# Altium schematic sheet RS485.SchDoc(RS485)
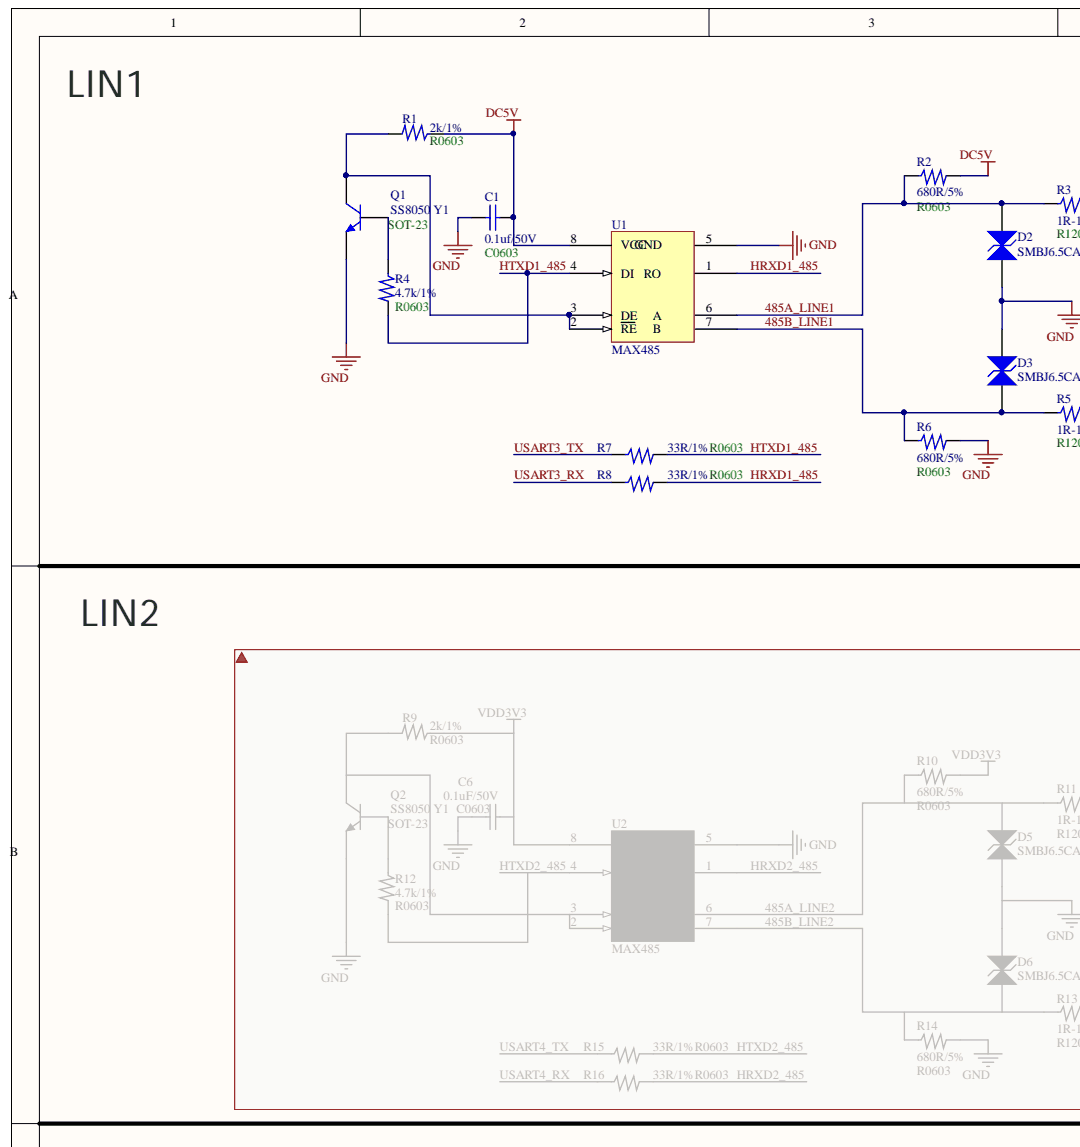
[diagram p0: RS485.SchDoc, top left]
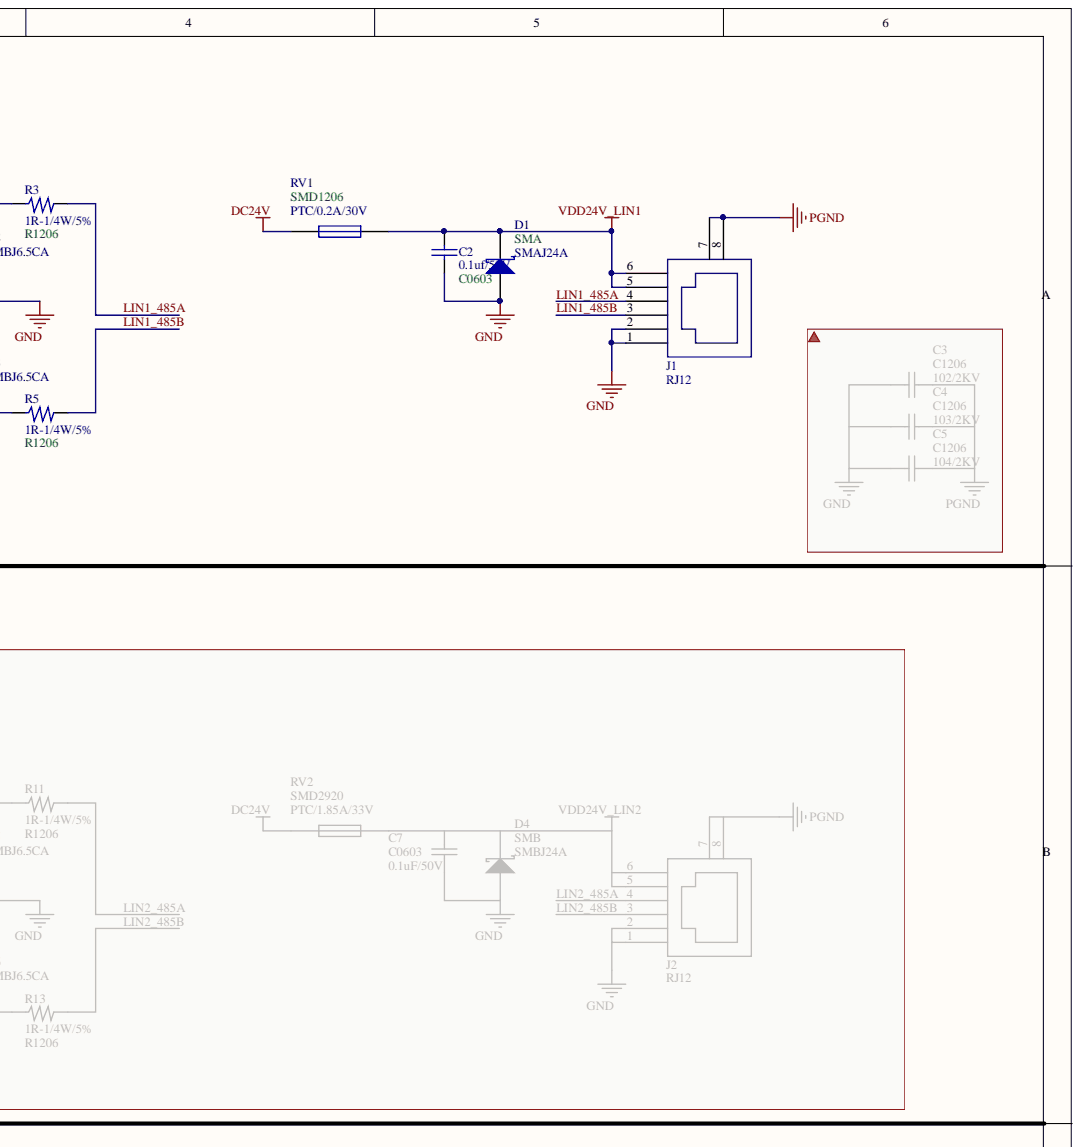
[diagram p1: RS485.SchDoc, top right]
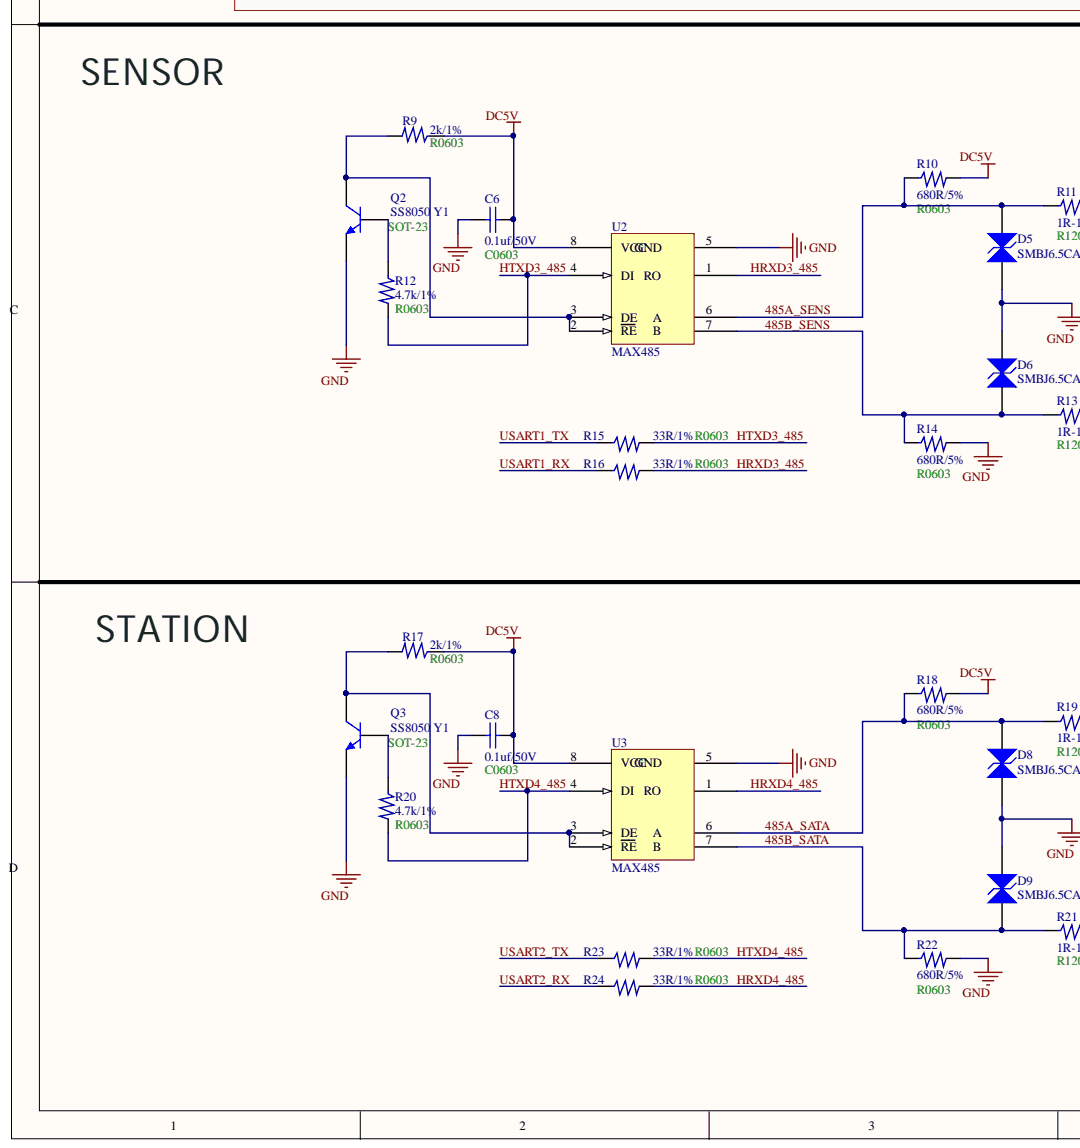
[diagram p2: RS485.SchDoc, bottom left]
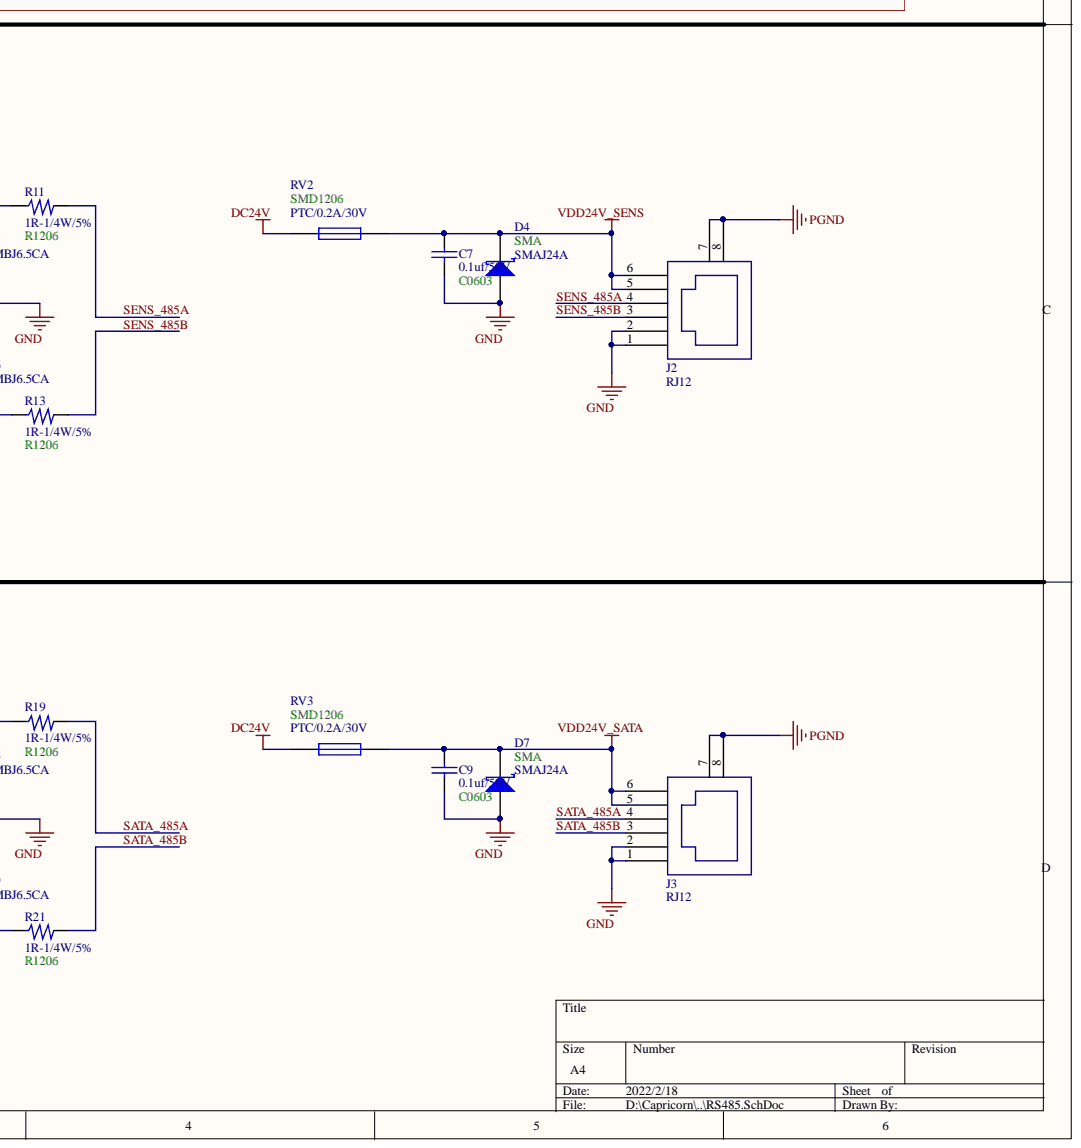
[diagram p3: RS485.SchDoc, bottom right]

## Parts
C1  0.1uf/50V  [C0603]
C2  0.1uf/50V  [C0603]
C6  0.1uf/50V  [C0603]
C7  0.1uf/50V  [C0603]
C8  0.1uf/50V  [C0603]
C9  0.1uf/50V  [C0603]
D1  SMAJ24A  PackageReference: SMA  [SMA(DO-214AC)_S1]
D2  SMBJ6.5CA  [SMB(DO-214AA)_S1]
D3  SMBJ6.5CA  [SMB(DO-214AA)_S1]
D4  SMAJ24A  PackageReference: SMA  [SMA(DO-214AC)_S1]
D5  SMBJ6.5CA  [SMB(DO-214AA)_S1]
D6  SMBJ6.5CA  [SMB(DO-214AA)_S1]
D7  SMAJ24A  PackageReference: SMA  [SMA(DO-214AC)_S1]
D8  SMBJ6.5CA  [SMB(DO-214AA)_S1]
D9  SMBJ6.5CA  [SMB(DO-214AA)_S1]
J1  RJ12  [RJ12]
J2  RJ12  [RJ12]
J3  RJ12  [RJ12]
Q1  SS8050 Y1  Package: SOT-23  [SOT-23(SOT-23-3)]
Q2  SS8050 Y1  Package: SOT-23  [SOT-23(SOT-23-3)]
Q3  SS8050 Y1  Package: SOT-23  [SOT-23(SOT-23-3)]
R1  2k/1%  [R0603]
R2  680R/5%  [R0603]
R3  1R-1/4W/5%  [R1206]
R4  4.7k/1%  [R0603]
R5  1R-1/4W/5%  [R1206]
R6  680R/5%  [R0603]
R7  33R/1%  [R0603]
R8  33R/1%  [R0603]
R9  2k/1%  [R0603]
R10  680R/5%  [R0603]
R11  1R-1/4W/5%  [R1206]
R12  4.7k/1%  [R0603]
R13  1R-1/4W/5%  [R1206]
R14  680R/5%  [R0603]
R15  33R/1%  [R0603]
R16  33R/1%  [R0603]
R17  2k/1%  [R0603]
R18  680R/5%  [R0603]
R19  1R-1/4W/5%  [R1206]
R20  4.7k/1%  [R0603]
R21  1R-1/4W/5%  [R1206]
R22  680R/5%  [R0603]
R23  33R/1%  [R0603]
R24  33R/1%  [R0603]
RV1  PTC/0.2A/30V  [SMD1206]
RV2  PTC/0.2A/30V  [SMD1206]
RV3  PTC/0.2A/30V  [SMD1206]
U1  MAX485  [SOIC-8_150mil]
U2  MAX485  [SOIC-8_150mil]
U3  MAX485  [SOIC-8_150mil]

## Nets
DC5V: C1.2 C6.2 C8.2 R1.2 R2.1 R9.2 R10.1 R17.2 R18.1 U1.8(VCC) U2.8(VCC) U3.8(VCC)
DC24V: RV1.1 RV2.1 RV3.1
GND: C1.1 C2.2 C6.1 C7.2 C8.1 C9.2 D1.1 D2.1 D3.1 D4.1 D5.1 D6.1 D7.1 D8.1 D9.1 J1.1 J1.2 J2.1 J2.2 J3.1 J3.2 Q1.2 Q2.2 Q3.2 R6.1 R14.1 R22.1 U1.5 U2.5 U3.5
PGND: J1.7 J1.8 J2.7 J2.8 J3.7 J3.8
VDD24V_LIN1: C2.1 D1.2 J1.5 J1.6 RV1.2
VDD24V_SATA: C9.1 D7.2 J3.5 J3.6 RV3.2
VDD24V_SENS: C7.1 D4.2 J2.5 J2.6 RV2.2
485A_LINE1: D2.2 R2.2 R3.2 U1.6
485A_SATA: D8.2 R18.2 R19.2 U3.6
485A_SENS: D5.2 R10.2 R11.2 U2.6
485B_LINE1: D3.2 R5.2 R6.2 U1.7
485B_SATA: D9.2 R21.2 R22.2 U3.7
485B_SENS: D6.2 R13.2 R14.2 U2.7
HRXD1_485: R8.1 U1.1
HRXD3_485: R16.1 U2.1
HRXD4_485: R24.1 U3.1
HTXD1_485: R4.1 R7.1 U1.4(DI)
HTXD3_485: R12.1 R15.1 U2.4(DI)
HTXD4_485: R20.1 R23.1 U3.4(DI)
LIN1_485A: J1.4 R3.1
LIN1_485B: J1.3 R5.1
SATA_485A: J3.4 R19.1
SATA_485B: J3.3 R21.1
SENS_485A: J2.4 R11.1
SENS_485B: J2.3 R13.1
USART1_RX: R16.2
USART1_TX: R15.2
USART2_RX: R24.2
USART2_TX: R23.2
USART3_RX: R8.2
USART3_TX: R7.2
NetQ1_1: Q1.1 R4.2
NetQ1_3: Q1.3 R1.1 U1.2(RE) U1.3(DE)
NetQ2_1: Q2.1 R12.2
NetQ2_3: Q2.3 R9.1 U2.2(RE) U2.3(DE)
NetQ3_1: Q3.1 R20.2
NetQ3_3: Q3.3 R17.1 U3.2(RE) U3.3(DE)

## Library data of the parts
C1 C2 C6-C9 = CAP: Capacitor; Component Kind: Standard
D1 D4 D7 = D Schottky: Schottky Diode; Code_JEDEC: DO-214-AA; Component Kind: Standard; LatestRevisionDate: 17-Jul-2002; LatestRevisionNote: Re-released for DXP Platform.; Library Name: Miscellaneous Devices.IntLib; PackageDescription: DO-214-AA/SMB; 2 C-Bend Leads; Body 5.3 x 3.6 mm, inc. leads (LxW); Published: 8-Jun-2000; Signal Integrity: D Schottky; Simulation: SKYDIODE; Publisher: Altium Limited
D2 D3 D5 D6 D8 D9 = SMB/DO-214AA P6SMB6.8CA: TVS; Component Kind: Standard; ComponentLink1Description: 供应商链接; ComponentLink1URL: http://www.szlcsc.com/product/details_79530.html; Library Name: Miscellaneous Devices LC.IntLib; Package: SMB/DO-214AA; Supplier: LC; SuppliersPartNumber: C78395
Q1-Q3 = SOT-23 SS8050 Y1: 三极管; Component Kind: Standard; ComponentLink1Description: 供应商链接; ComponentLink1URL: http://www.szlcsc.com/product/details_2507.html; Library Name: Miscellaneous Devices LC.IntLib; Supplier: LC; SuppliersPartNumber: C2150
R1-R24 = 电阻: Component Kind: Standard
RV1-RV3 = Fuse 1: Fuse; Component Kind: Standard; LatestRevisionDate: 17-Jul-2002; LatestRevisionNote: Re-released for DXP Platform.; Library Name: Miscellaneous Devices.IntLib; PackageDescription: Thru-Hole; 2 Leads; PackageReference: PIN-W2/E2.8; Published: 8-Jun-2000; Simulation: FUSE; Publisher: Altium Limited
J1-J3 = RJ12: Component Kind: Standard; Library Name: M4.SchLib
U1-U3 = MAX485: Component Kind: Standard; Library Name: M4.SchLib
